# Altium schematic sheet Sheet.SchDoc
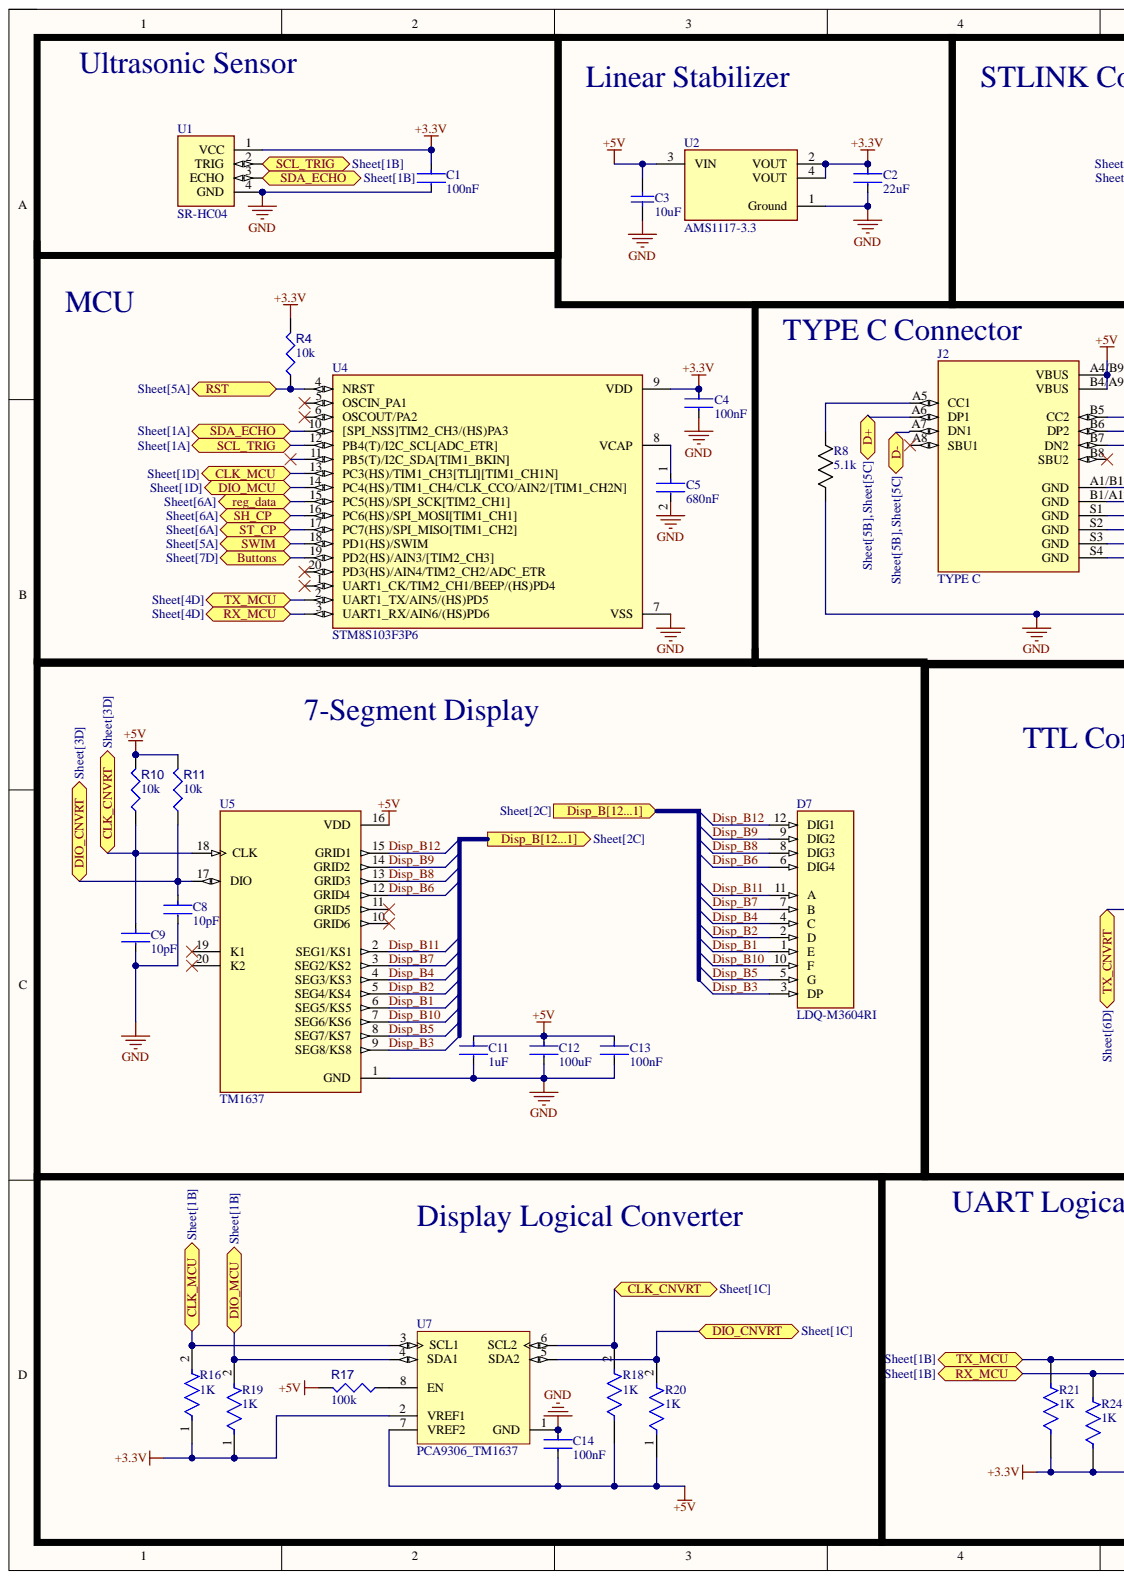
[diagram p0: Sheet.SchDoc, left]
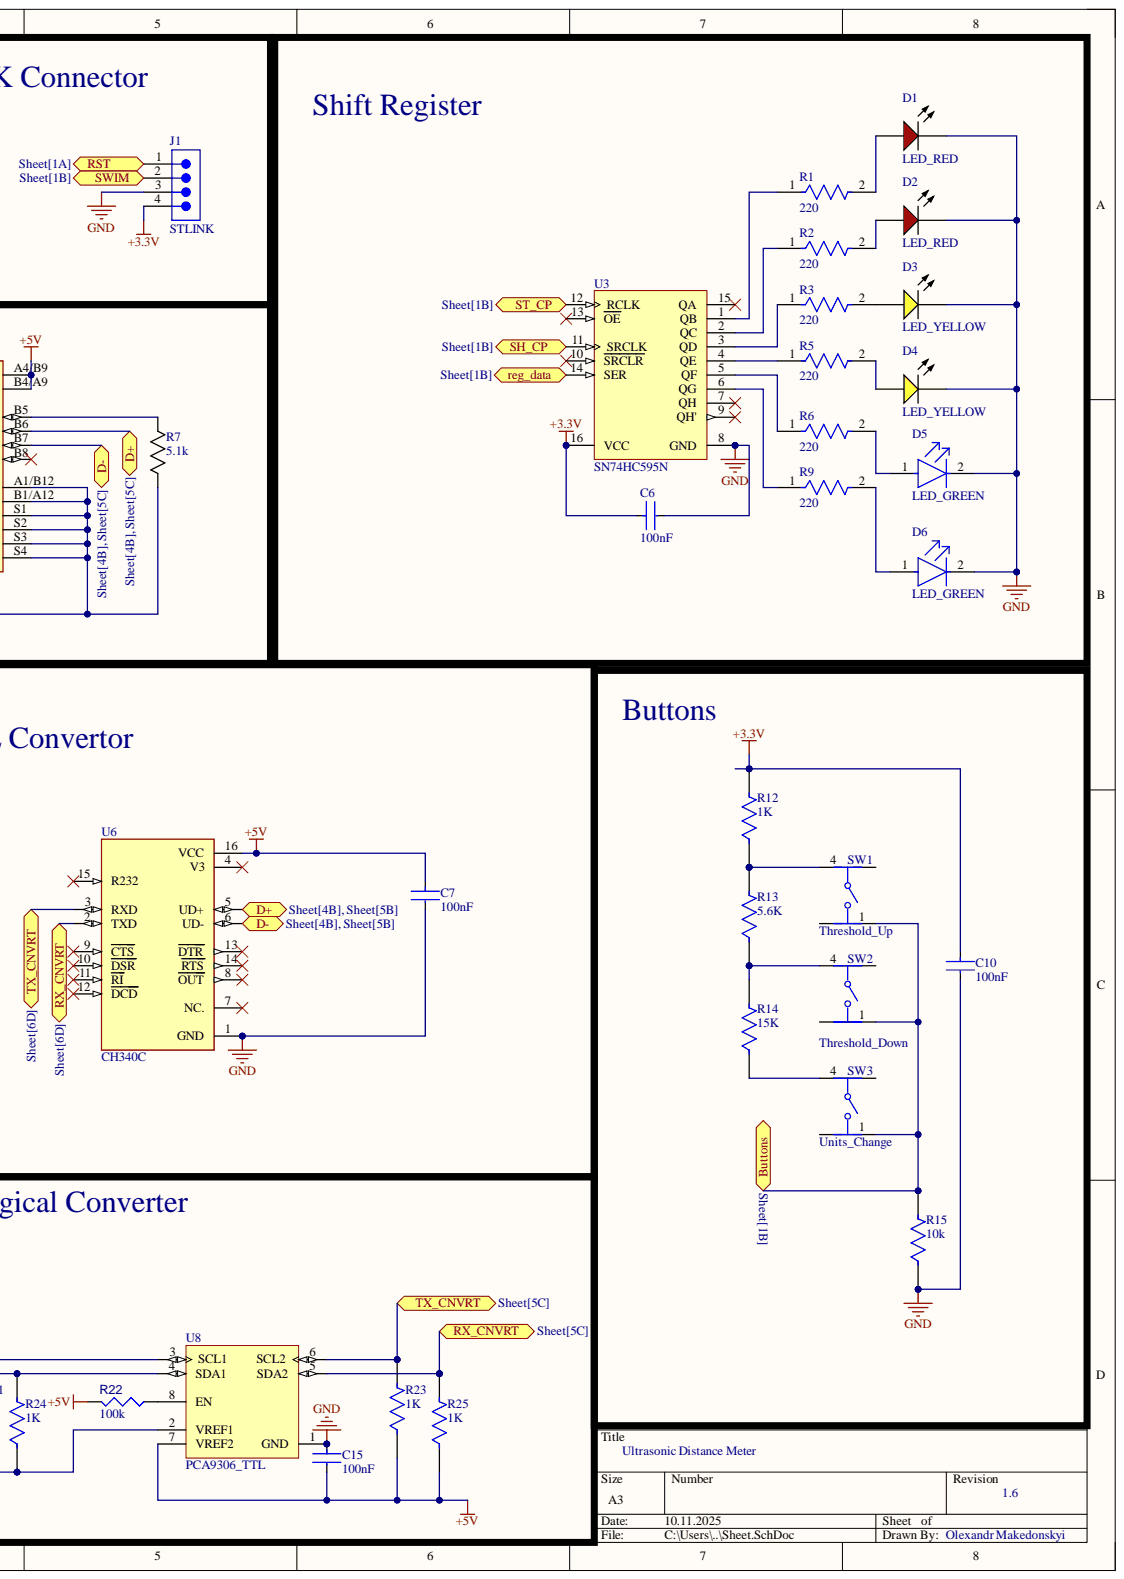
[diagram p1: Sheet.SchDoc, right]

## Parts
C1  100nF  [FP-C1206C-EB-MFG]
C2  22uF  [FP-1206-L_3_2_0_2-W_1_6_0-IPC_A]
C3  10uF  [CAPC1206(3216)190_L]
C4  100nF  [FP-C1206C-EB-MFG]
C5  680nF  [FP-CC1206-1_25-MFG]
C6  100nF  [FP-C1206C-EB-MFG]
C7  100nF  [FP-C1206C-EB-MFG]
C8  10pF  [FP-1206-L_3_2_0_2-W_1_6_0-IPC_C]
C9  10pF  [FP-1206-L_3_2_0_2-W_1_6_0-IPC_C]
C10  100nF  [FP-C1206C-EB-MFG]
C11  1uF  [FP-CC1206-FA-IPC_A]
C12  100uF  [FP-GRM31C-0_3-e0_3_0_8-MFG]
C13  100nF  [FP-C1206C-EB-MFG]
C14  100nF  [FP-C1206C-EB-MFG]
C15  100nF  [FP-C1206C-EB-MFG]
D1  LED_RED  [LUMX-SML-LX1206IC_V]
D2  LED_RED  [LUMX-SML-LX1206IC_V]
D3  LED_YELLOW  [LUMX-SML-LX1206YC-TR_V]
D4  LED_YELLOW  [LUMX-SML-LX1206YC-TR_V]
D5  LED_GREEN  [FP-SML-LX1206GC-TR-MFG]
D6  LED_GREEN  [FP-SML-LX1206GC-TR-MFG]
D7  LDQ-M3604RI  [LED_LDQ-M3604RI]
J1  STLINK  [61300411021]
J2  TYPE C  [GCT_USB4110GFA]
R1  220  [FP-SR1206-MFG]
R2  220  [FP-SR1206-MFG]
R3  220  [FP-SR1206-MFG]
R4  value 10k  [RESC3216X60X45ML15T20]  (hidden comment: Resistor 10k +/-1% 1206 250 mW)
R5  220  [FP-SR1206-MFG]
R6  220  [FP-SR1206-MFG]
R7  5.1k  [MCA 1206_VIS]
R8  5.1k  [MCA 1206_VIS]
R9  220  [FP-SR1206-MFG]
R10  value 10k  [RESC3216X60X45ML15T20]  (hidden comment: Resistor 10k +/-1% 1206 250 mW)
R11  value 10k  [RESC3216X60X45ML15T20]  (hidden comment: Resistor 10k +/-1% 1206 250 mW)
R12  1K  [FP-RMCF1206-IPC_A]
R13  5.6K  [FP-ESR18-IPC_C]
R14  15K  [FP-CRGCQ1206-IPC_C]
R15  10k  [FP-MCA1206-IPC_B]
R16  1K  [FP-RMCF1206-IPC_A]
R17  value 100k  [RESC3216X60X45ML15T20]  (hidden comment: Resistor 100k +/-1% 1206 250 mW)
R18  1K  [FP-RMCF1206-IPC_A]
R19  1K  [FP-RMCF1206-IPC_A]
R20  1K  [FP-RMCF1206-IPC_A]
R21  1K  [FP-RMCF1206-IPC_A]
R22  value 100k  [RESC3216X60X45ML15T20]  (hidden comment: Resistor 100k +/-1% 1206 250 mW)
R23  1K  [FP-RMCF1206-IPC_A]
R24  1K  [FP-RMCF1206-IPC_A]
R25  1K  [FP-RMCF1206-IPC_A]
SW1  (no value)  [FP-MJTP1230A-MFG]
SW2  (no value)  [FP-MJTP1230A-MFG]
SW3  (no value)  [FP-MJTP1230A-MFG]
U1  SR-HC04  [MODULE_4007]
U2  AMS1117-3.3  [FP-AMS1117-IPC_C]
U3  SN74HC595N  [DB0016A_M]
U4  STM8S103F3P6  [SOP65P640X120-20N]
U5  TM1637  [DIP778W43P254L2612H431Q20N]
U6  CH340C  [SOIC127P600X180-16N]
U7  PCA9306_TM1637  [DCT0008A_L]
U8  PCA9306_TTL  [DCT0008A_L]

## Ports
Buttons, CLK_CNVRT, CLK_MCU, D+, D-, DIO_CNVRT, DIO_MCU, Disp_B[12...1], reg_data, RST, RX_CNVRT, RX_MCU, SCL_TRIG, SDA_ECHO, SH_CP, ST_CP, SWIM, TX_CNVRT, TX_MCU

## Nets
+3.3V: C1.1 C2.1 C4.1 C6.1 C10.1 J1.4 R4.2 R12.2 R16.1 R19.1 R21.1 R24.1 U1.1(VCC) U2.2(VOUT) U2.4(VOUT) U3.16(VCC) U4.9(VDD) U7.2(VREF1) U8.2(VREF1)
+5V: C3.2 C7.1 C11.1 C12.2 C13.1 C14.2 C15.2 J2.A4/B9(VBUS) J2.B4/A9(VBUS) R10.2 R11.2 R17.2 R18.1 R20.1 R22.2 R23.1 R25.1 U2.3(VIN) U5.16(VDD) U6.16(VCC) U7.7(VREF2) U8.7(VREF2)
GND: C1.2 C2.2 C3.1 C4.2 C5.2 C6.2 C7.2 C8.2 C9.2 C10.2 C11.2 C12.1 C13.2 C14.1 C15.1 D1.2 D2.2 D3.2 D4.2 D5.2 D6.2 J1.3 J2.A1/B12(GND) J2.B1/A12(GND) J2.S1(GND) J2.S2(GND) J2.S3(GND) J2.S4(GND) R7.1 R8.1 R15.1 U1.4(GND) U2.1(Ground) U3.8(GND) U4.7(VSS) U5.1(GND) U6.1(GND) U7.1(GND) U8.1(GND)
Buttons: R15.2 SW1.1 SW2.1 SW3.1 U4.19(PD2(HS)/AIN3/[TIM2_CH3]) ; port Buttons
CLK_CNVRT: C9.1 R10.1 R18.2 U5.18(CLK) U7.6(SCL2) ; port CLK_CNVRT
CLK_MCU: R16.2 U4.13(PC3(HS)/TIM1_CH3[TLI][TIM1_CH1N]) U7.3(SCL1) ; port CLK_MCU
DIO_CNVRT: C8.1 R11.1 R20.2 U5.17(DIO) U7.5(SDA2) ; port DIO_CNVRT
DIO_MCU: R19.2 U4.14(PC4(HS)/TIM1_CH4/CLK_CCO/AIN2/[TIM1_CH2N]) U7.4(SDA1) ; port DIO_MCU
Disp_B1: D7.1(E) U5.6(SEG5/KS5)
Disp_B2: D7.2(D) U5.5(SEG4/KS4)
Disp_B3: D7.3(DP) U5.9(SEG8/KS8)
Disp_B4: D7.4(C) U5.4(SEG3/KS3)
Disp_B5: D7.5(G) U5.8(SEG7/KS7)
Disp_B6: D7.6(DIG4) U5.12(GRID4)
Disp_B7: D7.7(B) U5.3(SEG2/KS2)
Disp_B8: D7.8(DIG3) U5.13(GRID3)
Disp_B9: D7.9(DIG2) U5.14(GRID2)
Disp_B10: D7.10(F) U5.7(SEG6/KS6)
Disp_B11: D7.11(A) U5.2(SEG1/KS1)
Disp_B12: D7.12(DIG1) U5.15(GRID1)
reg_data: U3.14(SER) U4.15(PC5(HS)/SPI_SCK[TIM2_CH1]) ; port reg_data
RST: J1.1 R4.1 U4.4(NRST) ; port RST
RX_CNVRT: R25.2 U6.2(TXD) U8.5(SDA2) ; port RX_CNVRT
RX_MCU: R24.2 U4.3(UART1_RX/AIN6/(HS)PD6) U8.4(SDA1) ; port RX_MCU
SCL_TRIG: U1.2(TRIG) U4.12(PB4(T)/I2C_SCL[ADC_ETR]) ; port SCL_TRIG
SDA_ECHO: U1.3(ECHO) U4.10([SPI_NSS]TIM2_CH3/(HS)PA3) ; port SDA_ECHO
SH_CP: U3.11(SRCLK) U4.16(PC6(HS)/SPI_MOSI[TIM1_CH1]) ; port SH_CP
ST_CP: U3.12(RCLK) U4.17(PC7(HS)/SPI_MISO[TIM1_CH2]) ; port ST_CP
SWIM: J1.2 U4.18(PD1(HS)/SWIM) ; port SWIM
TX_CNVRT: R23.2 U6.3(RXD) U8.6(SCL2) ; port TX_CNVRT
TX_MCU: R21.2 U4.2(UART1_TX/AIN5/(HS)PD5) U8.3(SCL1) ; port TX_MCU
NetC5_1: C5.1 U4.8(VCAP)
NetD1_1: D1.1 R1.2
NetD2_1: D2.1 R2.2
NetD3_1: D3.1 R3.2
NetD4_1: D4.1 R5.2
NetD5_1: D5.1 R6.2
NetD6_1: D6.1 R9.2
NetJ2_A5: J2.A5(CC1) R8.2
NetJ2_A6: J2.A6(DP1) J2.B6(DP2) U6.5(UD+)
NetJ2_A7: J2.A7(DN1) J2.B7(DN2) U6.6(UD-)
NetJ2_B5: J2.B5(CC2) R7.2
NetR1_1: R1.1 U3.1(QB)
NetR2_1: R2.1 U3.2(QC)
NetR3_1: R3.1 U3.3(QD)
NetR5_1: R5.1 U3.4(QE)
NetR6_1: R6.1 U3.5(QF)
NetR9_1: R9.1 U3.6(QG)
NetR12_1: R12.1 R13.2 SW1.4
NetR13_1: R13.1 R14.2 SW2.4
NetR14_1: R14.1 SW3.4
NetR17_1: R17.1 U7.8(EN)
NetR22_1: R22.1 U8.8(EN)
N00001_[12..-1]: ; port Disp_B[12...1]

Not connected: J2 A8(SBU1) B8(SBU2); SW1 2 3; SW2 2 3; SW3 2 3; U3 7(QH) 9(QH') 10(SRCLR) 13(OE) 15(QA); U4 1(UART1_CK/TIM2_CH1/BEEP/(HS)PD4) 5(OSCIN_PA1) 6(OSCOUT/PA2) 11(PB5(T)/I2C_SDA[TIM1_BKIN]) 20(PD3(HS)/AIN4/TIM2_CH2/ADC_ETR); U5 10(GRID6) 11(GRID5) 19(K1) 20(K2); U6 4(V3) 7(NC.) 8(OUT) 9(CTS) 10(DSR) 11(RI) 12(DCD) 13(DTR) 14(RTS) 15(R232)

## Library data of the parts
C1 C4 C6 C7 C10 C13-C15 = CMP-03020-029382-1: C1206 0.10 μF X8R 2.00% 16 V; Manufacturer: KEMET; Manufacturer Part Number: C1206C104G4HACAUTO; Automotive Grade: Grade 0; Capacitance: 0.10 μF; Material: Ceramic; Temperature Coefficient: 15%; Tolerance: 0.02; Value: 0.10 μF; Voltage Rating: 16 V; Automotive: Yes; Category: Cap; Component Kind: Standard; ComponentLink2Description: Datasheet; ComponentLink2URL: https://content.kemet.com/datasheets/KEM_C1007_X8R_ULTRA_150C_SMD.pdf; Device Class L1: Passive Components; Device Class L2: Capacitors; Device Class L3: Ceramic Capacitors; Height: 0.88mm; Ipc Land Pattern Name: CAPC320160X78; Lead Free: Yes; Library Name: Altium Content Vault; Octopart: https://octopart.com/c1206c104g4hacauto-kemet-88099309; Package: C1206C-EB; RoHS: TRUE; Temperature: -55°C to +150°C; Temperature Characteristic: X8R; Temperature Range High: +150°C; Temperature Range Low: -55°C
C2 = CMP-04427-020232-1: General Purpose Ceramic Capacitor, 1206, 22uF, 20%, X7R, 15%, 6.3V; Dissipation Factor: 5%; Capacitance: 22uF; Case Code (Imperial): 1206; Case Code (Metric): 3216; Case/Package: 1206; Component Kind: Standard; Construction: Flat; Depth: 1.6mm; Dielectric: X7R; Height: 1.78mm; Lead Free: Lead Free; Length: 3.2mm; Library Name: Altium Content Vault; Max Operating Temperature: 125°C; Min Operating Temperature: -55°C; Mount: Surface Mount; Number of Pins: 2; Package Quantity: 2500; Packaging: Tape and Reel; Radiation Hardening: No; REACH SVHC: No SVHC; RoHS Compliant: Yes; Termination: SMD/SMT; Thickness: 0.07inch; Tolerance: 20%; Voltage Rating: 6.3V; Voltage Rating (DC): 6.3V; Width: 0.063inch
C3 = CMP-1037-04978-1: CAP 10uF 16V -20% to +80% 1206 (3216 Metric) Thickness 1.9mm SMD; Case-EIA: 1206; Case-Metric: 3216; Component Kind: Standard; Library Name: Altium Content Vault; Max Thickness: 1.9 mm; Rated Voltage: 16 V; Technology: SMT; Tolerance: -20% to +80%; Value: 10uF
C5 = CMP-03422-000845-1: CAP CER 0.68UF 50V X7R 1206; Manufacturer: Yageo; Manufacturer Part Number: CC1206KKX7R9BB684; Automotive Grade: NA; Material: Ceramic; Temperature Coefficient: 15%; Tolerance: 0.1; Automotive: No; Capacitance: 680 nF; Category: Cap; Component Kind: Standard; ComponentLink2Description: Datasheet; ComponentLink2URL: http://www.yageo.com/documents/recent/UPY-NP0X7R_HV_1KV-to-3KV_11.pdf; Device Class L1: Passive Components; Device Class L2: Capacitors; Device Class L3: Ceramic Capacitors; Digikey Description: CAP CER 0.68UF 50V X7R 1206; Footprint Url: http://www.yageo.com/exep/pages/download/literatures/UPY-C_GEN_24.pdf; Height: 1.45mm; Lead Free: Yes; Library Name: Altium Content Vault; Mouser Description: Cap Ceramic 0.68uF 50V X7R 10% Pad SMD 1206 125C T/R; Octopart: https://octopart.com/cc1206kkx7r9bb684-yageo-71334650; Package: CC1206-1.25; RoHS: TRUE; Temperature: -55°C to +125°C; Temperature Characteristic: X7R; Temperature Range High: +125°C; Temperature Range Low: -55°C; Value: 680 nF; Voltage Rating: 50 V
C8 C9 = CMP-04427-003168-1: Automotive Ceramic Capacitor, 1206, 10pF, 5%, NP0, 30ppm/°C, 500V; Dielectric: C0G; Capacitance: 10pF; Case Code (Imperial): 1206; Case Code (Metric): 3216; Case/Package: 1206; Component Kind: Standard; Height: 0.5mm; Length: 3.2mm; Library Name: Altium Content Vault; Max Operating Temperature: 125°C; Min Operating Temperature: -55°C; Package Quantity: 4000; Packaging: Tape and Reel; RoHS Compliant: Yes; Termination: SMD/SMT; Tolerance: 5%; Voltage Rating: 500V; Voltage Rating (DC): 500V; Width: 1.6mm
C11 = CMP-03422-000449-2: CAP CER X5R 1206; Component Kind: Standard; Library Name: Altium Content Vault; RoHS Compliant: Yes
C12 = CMP-06035-034850-1: Chip Multilayer Ceramic Capacitors for General Purpose, 1206, 100uF, X5R, 15%, 20%, 6.3V; Component Kind: Standard; Library Name: Altium Content Vault
D1 D2 = CMP-2000-07123-1: LED Red, 65 mW, -40 to 85 degC, 2-Pin SMD, RoHS, Tape and Reel; Manufacturer: Lumex; Part No: SML-LX1206IC-TR; Intensity: 6mcd; LED Material: GaAsP; Lens Appearance: ColorlessNonDiffused; Number of LEDs: 1; Peak Wavelength: 635nm; Pin_Count: 2; Reverse Voltage: 5(Min)V; Type: Uni-Color; Viewing Angle: 160; Color: Red; Component Kind: Standard; Datasheet URL: http://www.lumex.com/content/files/ProductAttachment/SML-LX1206IC-TR.pdf; Datasheet Version: Rev. J, 08/2012; Dimension: 3.2x1.6x1.1mm; Dominant Wavelength: N/A; ECCN: EAR99; Family: SML-LX1206IC; HTS: 8541402000; Lens Dimensions: 2x1.6x0.5mm; Library Name: Altium Content Vault; Manufacturer URL: http://www.lumexinstruments.com/; Mounting: SurfaceMount; Mounting Technology: SurfaceMount; Operating Temperature: -25to85C; Package Description: 2-Pin Surface Mount Device, Body 3.2 x 1.5 mm; Package Reference: SML-LX1206IC; Packaging: TapeandReel; RoHS: Unknown; Supplier: Lumex; Supplier Standard Pack: 3,000; Supplier_Package: SMD
D3 D4 = CMP-2000-07124-1: Yellow LED, Water Clear Len, 585 nm, 2.1 V, -40 to 85 degC, 2-Pin SMD, RoHS, Tape and Reel; Manufacturer: Lumex; Catalog Drawings: SML-LX1206SeriesTopSML-LX1206SeriesSideSML-LX1206SeriesBottomSML-LX1206SeriesFootprint; Current - Test: 20mA; Lens Color: Colorless; Lens Style/Size: RectanglewithFlatTop; Millicandela Rating: 6mcd; Online Catalog: SML-LX1206RectanglewithFlatTop1206PackageLEDs; Other Names: 67-1358-2LU60357TRSMLLX1206YCTR; Product Training Modules: LEDsBenefitsofCustomLEDandLCDTechnology; Viewing Angle: 160°; Voltage - Forward (Vf) (Typ): 2.1V; Wavelength - Peak: 585nm; Category: Optoelectronics; Color: Yellow; Component Kind: Standard; Datasheet URL: http://www.lumex.com/content/files/ProductAttachment/SML-LX1206YC-TR.pdf; Datasheet Version: Rev. K, 08/2012; Family: LEDIndication-Discrete; Features: -; Height (Max): 1.10mm; Lens Transparency: Clear; Library Name: Altium Content Vault; Manufacturer URL: http://www.lumexinstruments.com/; Mounting Technology: SurfaceMount; Mounting Type: SurfaceMount; Package / Case: 1206(3216Metric); Package Description: 2-Pin Surface Mount Device, Body 3.2 x 1.5 mm; Package Reference: SML-LX1206YC-TR; Packaging: Tape&Reel(TR); RoHS Information: RoHSCertofCompliance; Series: -; Size / Dimension: 3.20mmLx1.60mmW; Standard Package: 3,000; Supplier Device Package: 1206; Wavelength - Dominant: -
D5 D6 = CMP-2000-07122-2: LED GREEN CLEAR 1206 SMD; Approvals: UL; Current Rating: 25mA; Dominant Wavelength: 565nm; Forward Current: 20mA; Forward Voltage: 2.2V; Lens Color: Colorless; Lens Style: Rectangular; Luminous Intensity: 10mcd; Number of LEDs: 1; Power Rating: 65mW; Viewing Angle: 160°; Case Code (Imperial): 1206; Case Code (Metric): 3216; Case/Package: 1206; Color: Green; Component Kind: Standard; Height: 1.1mm; Illumination Color: Green; Lead Free: Lead Free; Length: 3.2mm; Lens Transparency: Clear; Library Name: Altium Content Vault; Max Operating Temperature: 85°C; Min Operating Temperature: -40°C; Mount: Surface Mount; Number of Pins: 2; Package Quantity: 1; Packaging: Tape and Reel; REACH SVHC: No SVHC; RoHS Compliant: Yes; Test Current: 20mA; Wavelength: 565nm; Width: 1.6mm
D7 = CMP-004-00032-1: Display, Seven Segment, 9.2MM, Red; MF: Lumex; Case/Package: None; Check_prices: https://www.snapeda.com/parts/LDQ-M3604RI/Lumex/view-part/?ref=eda; Component Kind: Standard; Component Type: Standard; Design Item ID: LDQ-M3604RI; MP: LDQ-M3604RI; Pins: 12; SnapEDA_Link: https://www.snapeda.com/parts/LDQ-M3604RI/Lumex/view-part/?ref=snap; Source: LDQ-M3604RI.SchLib; Library Name: Olexandr Makedonskyi
J1 = CMP-007-000000-1: NexarPartId: 31153320; Connector Type: Male Pin; Contact Resistance: 20m?; Current Rating: 3A; Gender: Male; Number of Contacts: 4; Operating Supply Voltage: 250V; Pitch: 2.54mm; Color: Black; Component Kind: Standard; Component Type: Standard; Contact Material: Copper; Contact Mating Length: 5.9944mm; Contact Plating: Gold; Design Item ID: CMP-1502-01063-3; Lead Pitch: 2.54mm; Mount: Through Hole; Number of Pins: 4; Number of Positions: 4; Number of Rows: 1; Pin Count: 4; REACH SVHC: Unknown; RoHS: Compliant; Source: Components - 25.08.25 - 11.48.SchLib; Termination: Solder; Library Name: Olexandr Makedonskyi
J2 = CMP-002-00071-1: USB-C (USB TYPE-C) USB 2.0 Receptacle Connector 24 (16+8 Dummy) Position Surface Mount, Right Angle; MF: Global Connector Technology; MP: USB4110GFA; Case/Package: None; Check_prices: https://www.snapeda.com/parts/USB4110GFA/Global+Connector+Technology/view-part/?ref=eda; Component Kind: Standard; Component Type: Standard; Design Item ID: USB4110GFA; Pins: 16; SnapEDA_Link: https://www.snapeda.com/parts/USB4110GFA/Global+Connector+Technology/view-part/?ref=snap; Source: USB4110GFA.SchLib; Library Name: Olexandr Makedonskyi
R1-R3 R5 R6 R9 = CMP-03412-006046-1: RES SMD 220 OHM 1% 1/2W 1206; Manufacturer: Yageo; Manufacturer Part Number: SR1206FR-7W220RL; Automotive Grade: Grade 0; Power Rating: 0.5W; Resistance: 220Ω; Tolerance: 0.01; Value: 220Ω; Voltage Rating: 200V; Automotive: Yes; Category: Res; Component Kind: Standard; ComponentLink2Description: Datasheet; ComponentLink2URL: http://www.yageo.com/documents/recent/PYu-SR_D_F%20tol_RoHS_L_3.pdf; Composition: Thick Film; Device Class L1: Passive Components; Device Class L2: Resistors; Device Class L3: Chip SMD Resistors; Digikey Description: RES SMD 220 OHM 1% 1/2W 1206; Footprint Url: http://www.yageo.com/exep/pages/download/literatures/PYu-R_Mount_10.pdf; Height: 0.65mm; Ipc Land Pattern Name: RESC310160X55; Lead Free: Yes; Library Name: Altium Content Vault; Octopart: https://octopart.com/sr1206fr-7w220rl-yageo-71414967; Package: SR1206; RoHS: TRUE; Series: SR; Temperature: -55°C to +155°C; Temperature Range High: +155°C; Temperature Range Low: -55°C
R4 R10 R11 = CMP-009-00016-5: Chip Resistor, 10 KOhm, +/- 1%, 0.25 W, -55 to 155 degC, 1206 (3216 Metric); Power: 250mW; Voltage Rating: 200V; Case/Package: 1206; Component Kind: Standard; Max Operating Temperature: 155°C; Min Operating Temperature: -55°C; Mounting Technology: SM; Pins: 2; RoHS Compliant: True; Tolerance: 1%; Library Name: Olexandr Makedonskyi
R7 R8 = CMP-00030-45006818-1: MCA12060D5101BP100 MCA Series Precision Thin Film Chip Resistor 1206 5.1K Ohm 0.1% Tolerance 0.25W ±25 ppm/K Surface Mount 2-Pin SMD...; Max Power Dissipation: 250mW; Resistance: 5.1kΩ; Temperature Coefficient: 25ppm/°C; Voltage Rating: 200V; Case/Package: 1206; Component Kind: Standard; Composition: Thin Film; Depth: 1.6mm; Height: 650µm; Lead Free: Lead Free; Length: 3.2004mm; Library Name: Altium Content Vault; Max Operating Temperature: 125°C; Military Standard: Not; Min Operating Temperature: -55°C; NexarPartId: 45006818; Number of Terminations: 2; Packaging: Tape & Reel (TR); Power Rating: 250mW; RoHS: Compliant; Tolerance: 0.1%; Width: 1.6002mm
R12 R16 R18-R21 R23-R25 = CMP-26527-006126-1: 1kΩ ±5% 0.25W 1206 Thick Film Chip Resistor AEC-Q200 compliant; Max Power Dissipation: 250mW; Resistance: 1kR; Temperature Coefficient: 200ppm/°C; Case Code (Imperial): 1206; Case Code (Metric): 3216; Case/Package: 1206; Component Kind: Standard; Contact Plating: Tin; Features: Automotive AEC-Q200; Height: 0.028inch; Length: 0.126inch; Library Name: Altium Content Vault; Max Operating Temperature: 155°C; Min Operating Temperature: -55°C; Mount: Surface Mount; Number of Terminations: 2; Packaging: Tape and Reel; Radiation Hardening: No; Resistor Type: Thick Film; RoHS Compliant: Yes; Series: RMCF; Tolerance: 5%; Width: 0.063inch
R13 = CMP-08839-028664-1: 5.6kΩ ±1% 0.5W 1206 Anti-Surge Chip Resistor AEC-Q200; Max Power Dissipation: 500mW; Resistance: 5.6kR; Temperature Coefficient: 100ppm/°C; Case/Package: 1206; Component Kind: Standard; Features: Automotive AEC-Q200; Library Name: Altium Content Vault; Max Operating Temperature: 155°C; Min Operating Temperature: -55°C; Number of Terminations: 2; Packaging: Tape and Reel; Resistor Type: Thick Film; RoHS Compliant: Yes; Series: ESR; Tolerance: 1%
R14 = CMP-03211-002524-1: CRGCQ1206 15KΩ 0.25W 5% ±100ppm/°C 200V; Manufacturer: TE Connectivity; Manufacturer Part Number: CRGCQ1206J15K; Automotive Grade: Grade 950; Tolerance: 0.05; Ambient Temperature Range High: +70°C; Automotive: Yes; Category: Res; Component Kind: Standard; ComponentLink2Description: Datasheet; ComponentLink2URL: https://www.te.com/commerce/DocumentDelivery/DDEController?Action=showdoc&DocId=Data+Sheet%7F1773204-3%7F1%7Fpdf%7FEnglish%7FENG_DS_1773204-3_1.pdf%7F4-2176347-959; Composition: Thick Film; Device Class L1: Passive Components; Device Class L2: Resistors; Device Class L3: Chip SMD Resistors; Height: 0.65mm; Ipc Land Pattern Name: RESC310155X55; Lead Free: Yes; Library Name: Altium Content Vault; Octopart: https://octopart.com/crgcq1206j15k-te+connectivity-91018310; Package: CRGCQ1206; Power Rating: 0.25W; Resistance: 15KΩ; RoHS: TRUE; Series: CRGCQ; Temperature: -55°C to +155°C; Temperature Coefficient: ±100ppm/°C; Temperature Range High: +155°C; Temperature Range Low: -55°C; Value: 15KΩ; Voltage Rating: 200V
R15 = CMP-02407-011266-1: Res MC AT Professional Thin Film 1206 10kΩ 0.5% 0.4W ±25ppm/°C Molded Paper T/R; Max Power Dissipation: 400mW; Resistance: 10kR; Voltage Rating: 200V; Case Code (Imperial): 1206; Case Code (Metric): 3216; Case/Package: 1206; Component Kind: Standard; Features: Automotive AEC-Q200; Height: 0.55mm; Length: 3.2mm; Library Name: Altium Content Vault; Max Operating Temperature: 175°C; Min Operating Temperature: -55°C; Number of Terminations: 2; Packaging: Tape and Reel; Power Rating: 400mW; Resistor Type: Thin Film; RoHS Compliant: Yes; Series: MCA AT - Professional; Temperature Coefficient: 25ppm/°C; Termination: SMD/SMT; Tolerance: 0.5%; Weight: 0.000325oz; Width: 1.6mm
R17 R22 = CMP-009-00025-5: Chip Resistor, 100 KOhm, +/- 1%, 0.25 W, -55 to 155 degC, 1206 (3216 Metric); Power: 250mW; Voltage Rating: 200V; Case/Package: 1206; Component Kind: Standard; Max Operating Temperature: 155°C; Min Operating Temperature: -55°C; Mounting Technology: SM; Pins: 2; RoHS Compliant: True; Tolerance: 1%; Library Name: Olexandr Makedonskyi
SW1-SW3 = CMP-47024-000004-1: SWITCH TACTILE SPST-NO 0.05A 12V; Actuator Color: Black; Actuator Length: 1.4mm; Actuator Material: Thermoplastic; Actuator Type: Standard; Contact Resistance: 100mR; Current Rating: 50mA; Electrical Life: 100000Cycles; Illumination Type: Non-Illuminated; Operating Force: 160gf; Switch Function: Off-Mom; Switch Travel: 0.25mm; Throw Configuration: SPST; Color: Black; Component Kind: Standard; Contact Material: Bronze; Contact Plating: Silver; Library Name: Altium Content Vault; Max Operating Temperature: 70°C; Max Voltage Rating (DC): 12V; Mechanical Life: 100000Cycles; Min Operating Temperature: -20°C; Mount: Through Hole; Orientation: Straight; Package Quantity: 2000; Packaging: Bulk; REACH SVHC: No SVHC; RoHS Compliant: Yes; Series: MJTP; Termination: PC Pin; Voltage Rating (DC): 12V
U1 = CMP-015-000000-1: Ultrasonic Distance Sensor - 3V or 5V - HC-SR04 compatible - RCWL-1601; MF: Adafruit Industries; MP: 4007; Check_prices: https://www.snapeda.com/parts/4007/Adafruit+Industries+LLC/view-part/?ref=eda; Component Kind: Standard; Component Type: Standard; Design Item ID: 4007; Package: None; Pin Count: 4; SnapEDA_Link: https://www.snapeda.com/parts/4007/Adafruit+Industries+LLC/view-part/?ref=snap; Source: 4007.SchLib; Library Name: Olexandr Makedonskyi
U2 = CMP-209535-000001-1: LDO Voltage Regulators 1A, 3.3V; Manufacturer: Advanced Monolithic Systems; Dropout Voltage: 1.1V; Max Junction Temp: +125°C; Max Power Dissipation: 1.2W; Max Supply Voltage: 15V; Nominal Supply Current: 5mA; Number Of Outputs: 1; Output Type: Fixed; Standoff Height: 0.64mm; Automotive: No; Category: IC; Component Kind: Standard; ComponentLink2Description: Datasheet; ComponentLink2URL: http://www.advanced-monolithic.com/pdf/ds1117.pdf; Device Class L1: Integrated Circuits (ICs); Device Class L2: Power Management ICs; Device Class L3: Voltage Regulators - Linear; Height: 1.8mm; Ipc Land Pattern Name: SOT229P700X180-4; Lead Free: Yes; Library Name: Altium Content Vault; Manufacturer Part Number: AMS1117-3.3; Octopart: https://octopart.com/ams1117-3.3-advanced+monolithic+systems-25899300; Output Current: 1A; Output Voltage: 3.3V; Package: AMS1117; RoHS: TRUE; Temperature: -40°C to +125°C; Temperature Range High: +125°C; Temperature Range Low: -40°C
U3 = CMP-0780-00077-3: 8-Bit Shift Registers With 3-State Output Registers, DB0016A, LARGE T&R; Manufacturer: Texas Instruments; 3-State Output: Yes; F @ Nom Voltage(Max)(Mhz): 28; ICC @ Nom Voltage(Max)(mA): 0.08; Input Type: CMOS; Output Drive (IOL/IOH)(Max)(mA): 7.8/-7.8; Rating: Military; Technology Family: HC; tpd @ Nom Voltage(Max)(ns): 34; VCC(Max)(V): 6; VCC(Min)(V): 2; Code_JEDEC: MO-150; Component Kind: Standard; DatasheetVersion: SCLS041H; Generic Part Number: SN74HC595; Library Name: Altium Content Vault; Operating Temperature Range(C): -40 to 85; Output Type: CMOS; PackageReference: DB0016A; PackageVersion: http://www.ti.com/lit/ml/mpds507/mpds507.pdf; Voltage(Nom)(V): 6
U4 = CMP-031-00006-1: STM8 series Microcontroller IC 8-Bit 16MHz 8KB (8K x 8) FLASH 20-TSSOP; MF: STMicroelectronics; Case/Package: TSSOP-20 STMicroelectronics; Check_prices: https://www.snapeda.com/parts/STM8S103F3P6/STMicroelectronics/view-part/?ref=eda; Component Kind: Standard; Component Type: Standard; Design Item ID: STM8S103F3P6; MP: STM8S103F3P6; Pins: 20; SnapEDA_Link: https://www.snapeda.com/parts/STM8S103F3P6/STMicroelectronics/view-part/?ref=snap; Source: STM8S103F3P6.SchLib; Library Name: Olexandr Makedonskyi
U5 = CMP-005-000000-1: LED Drive Control Special Circuit; MF: Titan Micro Electronics; Check_prices: https://www.snapeda.com/parts/TM1637/Titan+Micro+Electronics/view-part/?ref=eda; Component Kind: Standard; Component Type: Standard; Design Item ID: TM1637; MP: TM1637; Package: None; Pin Count: 20; SnapEDA_Link: https://www.snapeda.com/parts/TM1637/Titan+Micro+Electronics/view-part/?ref=snap; Source: TM1637.SchLib; Library Name: Olexandr Makedonskyi
U6 = CMP-030-000000-1: USB to serial chip CH340; MF: WCH; Availability: Not in stock; Check_prices: https://www.snapeda.com/parts/CH340C/WCH/view-part/?ref=eda; Component Kind: Standard; Component Type: Standard; Design Item ID: CH340C; MP: CH340C; Package: None; Pin Count: 16; Price: None; SnapEDA_Link: https://www.snapeda.com/parts/CH340C/WCH/view-part/?ref=snap; Source: CH340C.SchLib; Library Name: Olexandr Makedonskyi
U7 U8 = CMP-0314-00283-2: Dual Bi-Directional I2C-Bus and SMBus Voltage Level-Translator, 0 to 5.5 V, -40 to 85 degC, 8-pin SM8 (DCT), Green (RoHS & no Sb/Br); Manufacturer: Texas Instruments; PartNumber: PCA9306DCTR; 5V Tolerant I/O: Yes; fSCLK(Max)(MHz): 400; Function: Voltage Translator; No. of Outputs: 2; Rating: Catalog; SubFamily: I2C Special Function; tpd max(ns): 1.5; Vcc range(V): 0 to 5.5; Code_JEDEC: MO-187-DA; Component Kind: Standard; ComponentLink1Description: Datasheet; ComponentLink1URL: http://www.ti.com/general/docs/lit/getliterature.tsp?genericPartNumber=PCA9306&fileType=pdf; ComponentLink2Description: Package Specification; ComponentLink2URL: http://www.ti.com/litv/pdf/mpds049b; DatasheetVersion: SCPS113J; Eco-Plan: Green (RoHS & no Sb/Br); Enable Pin: Yes; Library Name: Altium Content Vault; Max Frequency(kHz): 400; Mounting Technology: Surface Mount; On-Chip Power-on-Reset: No; Open Drain I/O Type: Yes; PackageDescription: 8-Pin Thin Small Outline Package 3 x 2.8 mm Body ,0.65 mm Pitch; PackageReference: DCT0008A; PackageVersion: revC, Sep-2002
